# Altium schematic sheet GNSS_FE.SchDoc
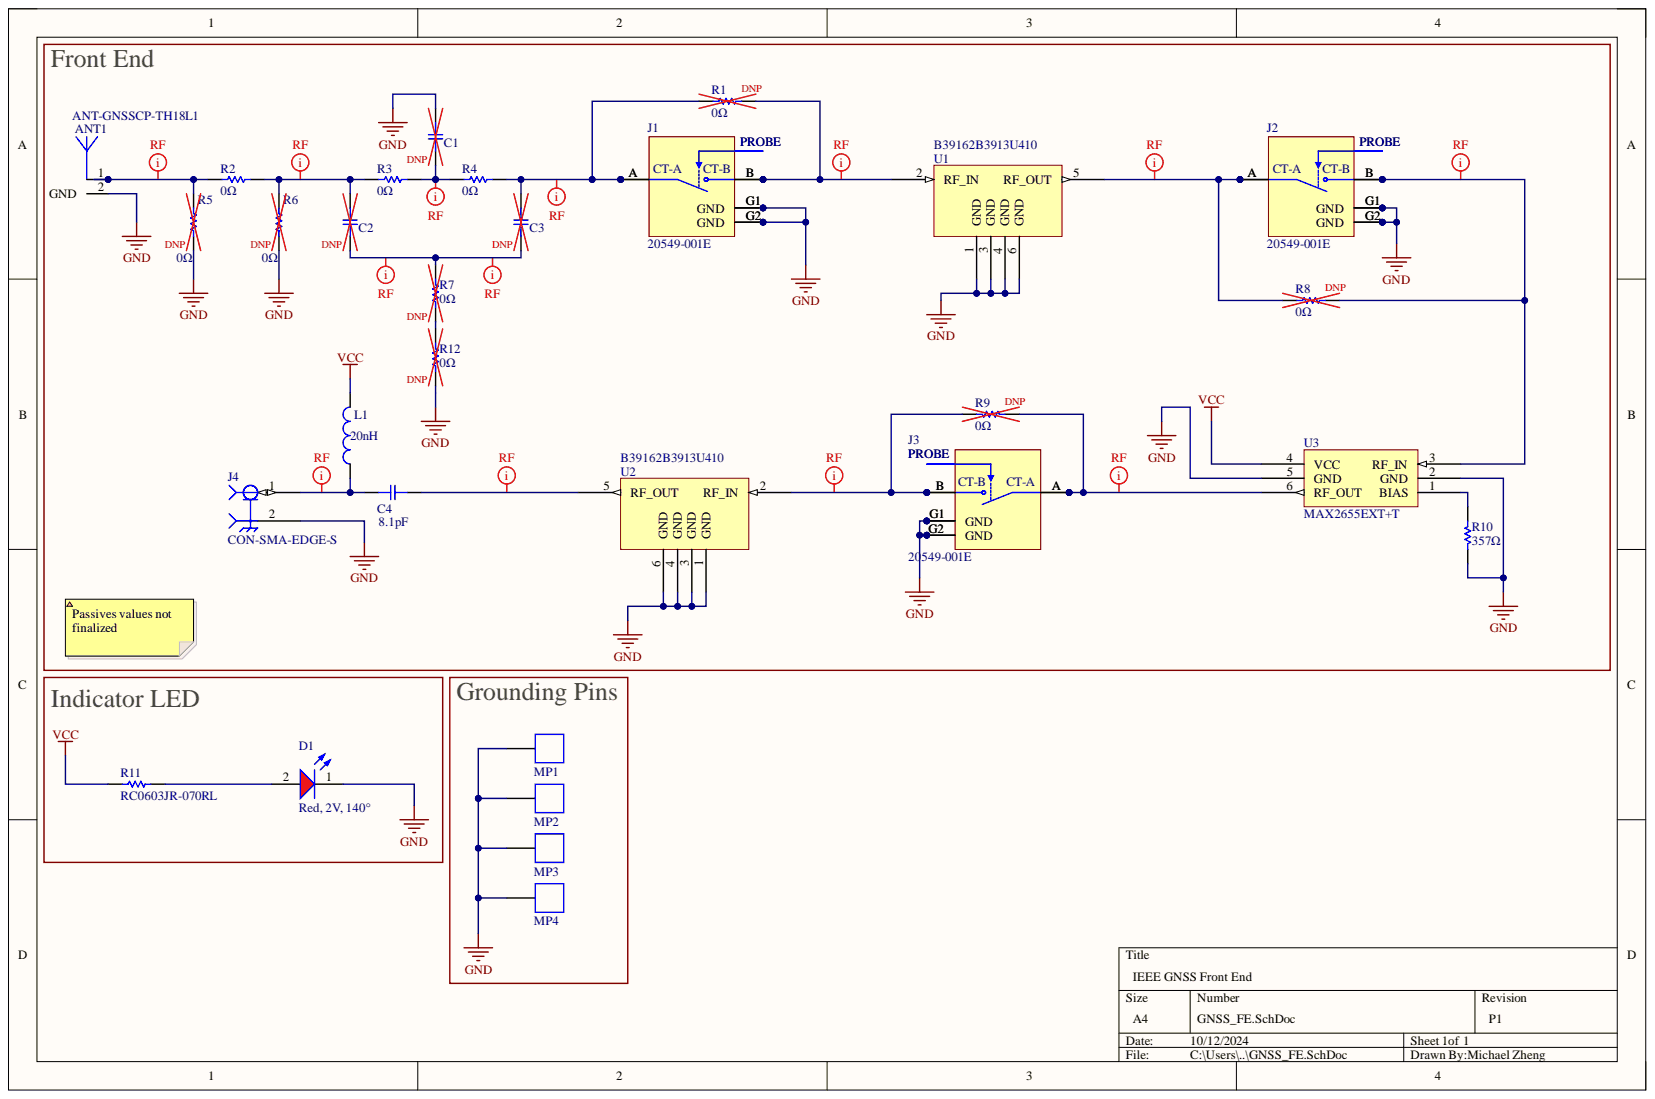
[diagram p0: GNSS_FE.SchDoc]

## Parts
ANT1  ANT-GNSSCP-TH18L1  [ANT-GNSSCP-TH18L1]
C1  GRM188R61A105KA61D  [CAP_MURATA_0603]  (value not drawn)
C2  GRM188R61A105KA61D  [CAP_MURATA_0603]  (value not drawn)
C3  GRM188R61A105KA61D  [CAP_MURATA_0603]  (value not drawn)
C4  Capacitance: 8.1pF  [CAP_MURATA_0603]  (hidden comment: GRM188R61A105KA61D)
D1  Red, 2V, 140°  Viewing Angle: 140°  [WL-SMCW]
J1  20549-001E  [IPEX_20549-001E]
J2  20549-001E  [IPEX_20549-001E]
J3  20549-001E  [IPEX_20549-001E]
J4  CON-SMA-EDGE-S  [RFSOLUTIONS_CON-SMA-EDGE-S]
L1  value 20nH  [INDC1006X60N]  (hidden comment: LQW15AN3N6C10D)
MP1  36900000  [36900000]  (value not drawn)
MP2  36900000  [36900000]  (value not drawn)
MP3  36900000  [36900000]  (value not drawn)
MP4  36900000  [36900000]  (value not drawn)
R1  RC0603JR-070RL  Resistance: 0Ω  [RC0603JR-070RL]
R2  RC0603JR-070RL  Resistance: 0Ω  [RC0603JR-070RL]
R3  RC0603JR-070RL  Resistance: 0Ω  [RC0603JR-070RL]
R4  RC0603JR-070RL  Resistance: 0Ω  [RC0603JR-070RL]
R5  RC0603JR-070RL  Resistance: 0Ω  [RC0603JR-070RL]
R6  RC0603JR-070RL  Resistance: 0Ω  [RC0603JR-070RL]
R7  RC0603JR-070RL  Resistance: 0Ω  [RC0603JR-070RL]
R8  RC0603JR-070RL  Resistance: 0Ω  [RC0603JR-070RL]
R9  RC0603JR-070RL  Resistance: 0Ω  [RC0603JR-070RL]
R10  Resistance: 357Ω  [RC0603JR-070RL]
R11  RC0603JR-070RL  [RC0603JR-070RL]
R12  RC0603JR-070RL  Resistance: 0Ω  [RC0603JR-070RL]
U1  B39162B3913U410  [B39162B3913U410]
U2  B39162B3913U410  [B39162B3913U410]
U3  MAX2655EXT+T  [MAX2655EXT+T]

## Nets
GND: ANT1.2 C1.2 D1.1 J1.G1(GND) J1.G2(GND) J2.G1(GND) J2.G2(GND) J3.G1(GND) J3.G2(GND) J4.2 MP1.1 MP2.1 MP3.1 MP4.1 R5.1 R6.1 R10.2 R12.2 U1.1(GND) U1.3(GND) U1.4(GND) U1.6(GND) U2.1(GND) U2.3(GND) U2.4(GND) U2.6(GND) U3.2(GND) U3.5(GND)
VCC: L1.2 R11.1 U3.4(VCC)
NetANT1_1: ANT1.1 R2.2 R5.2
NetC1_1: C1.1 R3.1 R4.2
NetC2_1: C2.1 C3.1 R7.1
NetC2_2: C2.2 R2.1 R3.2 R6.2
NetC3_2: C3.2 J1.A R1.2 R4.1
NetC4_1: C4.1 U2.5(RF_OUT)
NetC4_2: C4.2 J4.1 L1.1
NetD1_2: D1.2 R11.2
NetJ1_B: J1.B R1.1 U1.2(RF_IN)
NetJ2_A: J2.A R8.2 U1.5(RF_OUT)
NetJ2_B: J2.B R8.1 U3.3(RF_IN)
NetJ3_A: J3.A R9.2 U3.6(RF_OUT)
NetJ3_B: J3.B R9.1 U2.2(RF_IN)
NetR7_2: R7.2 R12.1
NetR10_1: R10.1 U3.1(BIAS)

## Library data of the parts
ANT1 = ANT-GNSSCP-TH18L1: CERAMIC PATCH GNSS ANTENNA; Component Kind: Standard; Library Name: Symbols.SchLib
C1-C4 = GRM188R61A105KA61D: CAP CER 1UF 10V X5R 0603; Component Kind: Standard; Library Name: Symbols.SchLib
  C1-C3: Capacitance: 1uF
D1 = 150060RS75000: WL-SMCW Mono-color Chip LED Waterclear, SMT, size 0603, Red, 2V, 140°; Manufacturer: Wurth Elektronik; Case/Size Code: 0603; Chip Technology: AlInGaP; Dominant Wavelength: 625nm; Luminous Intensity: 250mcd; Peak Wavelength: 630nm; Category: Signal LEDs; Component Kind: Standard; ComponentLink1Description: Website Link; ComponentLink1URL: https://www.we-online.com/redexpert/article/150060RS75000?ad; ComponentLink2Description: Datasheet Link; ComponentLink2URL: https://www.we-online.com/redexpert/spec/150060RS75000?ad; Emitting Color: Red; Forward Voltage: 2V; Height: 0.7mm; Length: 1.6mm; Library Name: Symbols.SchLib; Manufacturer Part Number: 150060RS75000; Match Code: WL-SMCW; Mount: Surface Mount; Operating Temperature Max: 85°C; Operating Temperature Min: -40°C; Packaging: Tape and Reel; Width: 0.8mm
J1-J3 = 20549-001E: 1 POS Vertical SMD Coaxial RF switch connector with a 2.3 x 2.3 mm footprint and support to 11 GHz, MHC; MF: I-PEX; Availability: Warning; Check_prices: https://www.snapeda.com/parts/20549-001E/I-PEX/view-part/3220455/?ref=eda; Component Kind: Standard; Library Name: Symbols.SchLib; MP: 20549-001E; Package: None; Price: None; SnapEDA_Link: https://www.snapeda.com/parts/20549-001E/I-PEX/view-part/3220455/?ref=snap
J4 = CON-SMA-EDGE-S: CONN SMA JACK STR EDGE MNT; Component Kind: Standard; Library Name: Symbols.SchLib
L1 = LQW15AN3N6C10D: FIXED IND 3.6NH 900MA 40 MOHM; Availability: Unavailable; Component Kind: Standard; Library Name: Symbols.SchLib; MP: LQW15AN3N6C10D; Package: None; Price: None
MP1-MP4 = 36900000: WE-SHC Shielding Cabinet, Clip, Tin Plated, Tape and Reel, 6.5x0.8x1.27mm; Manufacturer: Wurth Elektronik; Base Material: Steel; Category: EMC Shielding; Component Kind: Standard; ComponentLink1Description: Website Link; ComponentLink1URL: https://www.we-online.com/redexpert/article/36900000?ad; ComponentLink2Description: Datasheet Link; ComponentLink2URL: https://www.we-online.com/redexpert/spec/36900000?ad; Height: 1.27mm; Length: 6.5mm; Library Name: Symbols.SchLib; Manufacturer Part Number: 36900000; Match Code: WE-SHC; Mount: Surface Mount; Operating Temperature Max: 125°C; Operating Temperature Min: -40°C; Packaging: Tape and Reel; Plating: Tin Plated; Type: Clip; Width: 0.8mm
R1-R10 R12 = RC0603JR-070RL: Chip Resistor, 0 Ohm, +/- 5%, 0.1 W, -55 to 155 degC, 0603 (1608 Metric), RoHS, Tape and Reel; Manufacturer: Yageo; Power Rating: 100mW; Temperature Coefficient: 200ppm/degreesC; Voltage Rating: 50V; Case Code (Imperial): 0603; Case Code (Metric): 1608; Case/Package: 0603; Component Kind: Standard; Composition: ThickFilm; Contact Plating: Tin; Datasheet URL: http://www.yageo.com/NewPortal/yageodocoutput?fileName=/pdf/R-Chip/PYu-RC_Group_51_RoHS_L_5.pdf; Datasheet Version: Rev. 5, 10/2016; Depth: 0.8mm; Height: 0.45mm; Lead Free: LeadFree; Length: 1.6mm; Library Name: Symbols.SchLib; Manufacturer URL: http://www.yageo.com/; Max Operating Temperature: 155degC; Military Standard: Not; Min Operating Temperature: -55degC; Number of Pins: 2; Package Description: 2-Pin Surface Mount Device, Body 1.6 x 0.8 mm; Package Quantity: 5000; Package Reference: 0603; Packaging: TapeandReel; Radiation Hardening: No; REACH SVHC: NoSVHC; RoHSCompliant: true; Termination: SMD/SMT; Tolerance: 5%; Voltage Rating (DC): 50V; Width: 0.8mm
R11 = RC0603JR-070RL: Chip Resistor, 0 Ohm, +/- 5%, 0.1 W, -55 to 155 degC, 0603 (1608 Metric), RoHS, Tape and Reel; Manufacturer: Yageo; Power Rating: 100mW; Resistance: 0mOhm; Temperature Coefficient: 200ppm/degreesC; Voltage Rating: 50V; Case Code (Imperial): 0603; Case Code (Metric): 1608; Case/Package: 0603; Component Kind: Standard; Composition: ThickFilm; Contact Plating: Tin; Datasheet URL: http://www.yageo.com/NewPortal/yageodocoutput?fileName=/pdf/R-Chip/PYu-RC_Group_51_RoHS_L_5.pdf; Datasheet Version: Rev. 5, 10/2016; Depth: 0.8mm; Height: 0.45mm; Lead Free: LeadFree; Length: 1.6mm; Library Name: Symbols.SchLib; Manufacturer URL: http://www.yageo.com/; Max Operating Temperature: 155degC; Military Standard: Not; Min Operating Temperature: -55degC; Number of Pins: 2; Package Description: 2-Pin Surface Mount Device, Body 1.6 x 0.8 mm; Package Quantity: 5000; Package Reference: 0603; Packaging: TapeandReel; Radiation Hardening: No; REACH SVHC: NoSVHC; RoHSCompliant: true; Termination: SMD/SMT; Tolerance: 5%; Voltage Rating (DC): 50V; Width: 0.8mm
U1 U2 = B39162B3913U410: FILTER SAW 1.588GHZ 6SMD; Component Kind: Standard; Library Name: Symbols.SchLib
U3 = MAX2655EXT+T: IC AMP GPS 1.4GHZ-1.7GHZ SC70-6; Component Kind: Standard; Library Name: Symbols.SchLib
